# Altium schematic sheet 14-GPIO.SchDoc
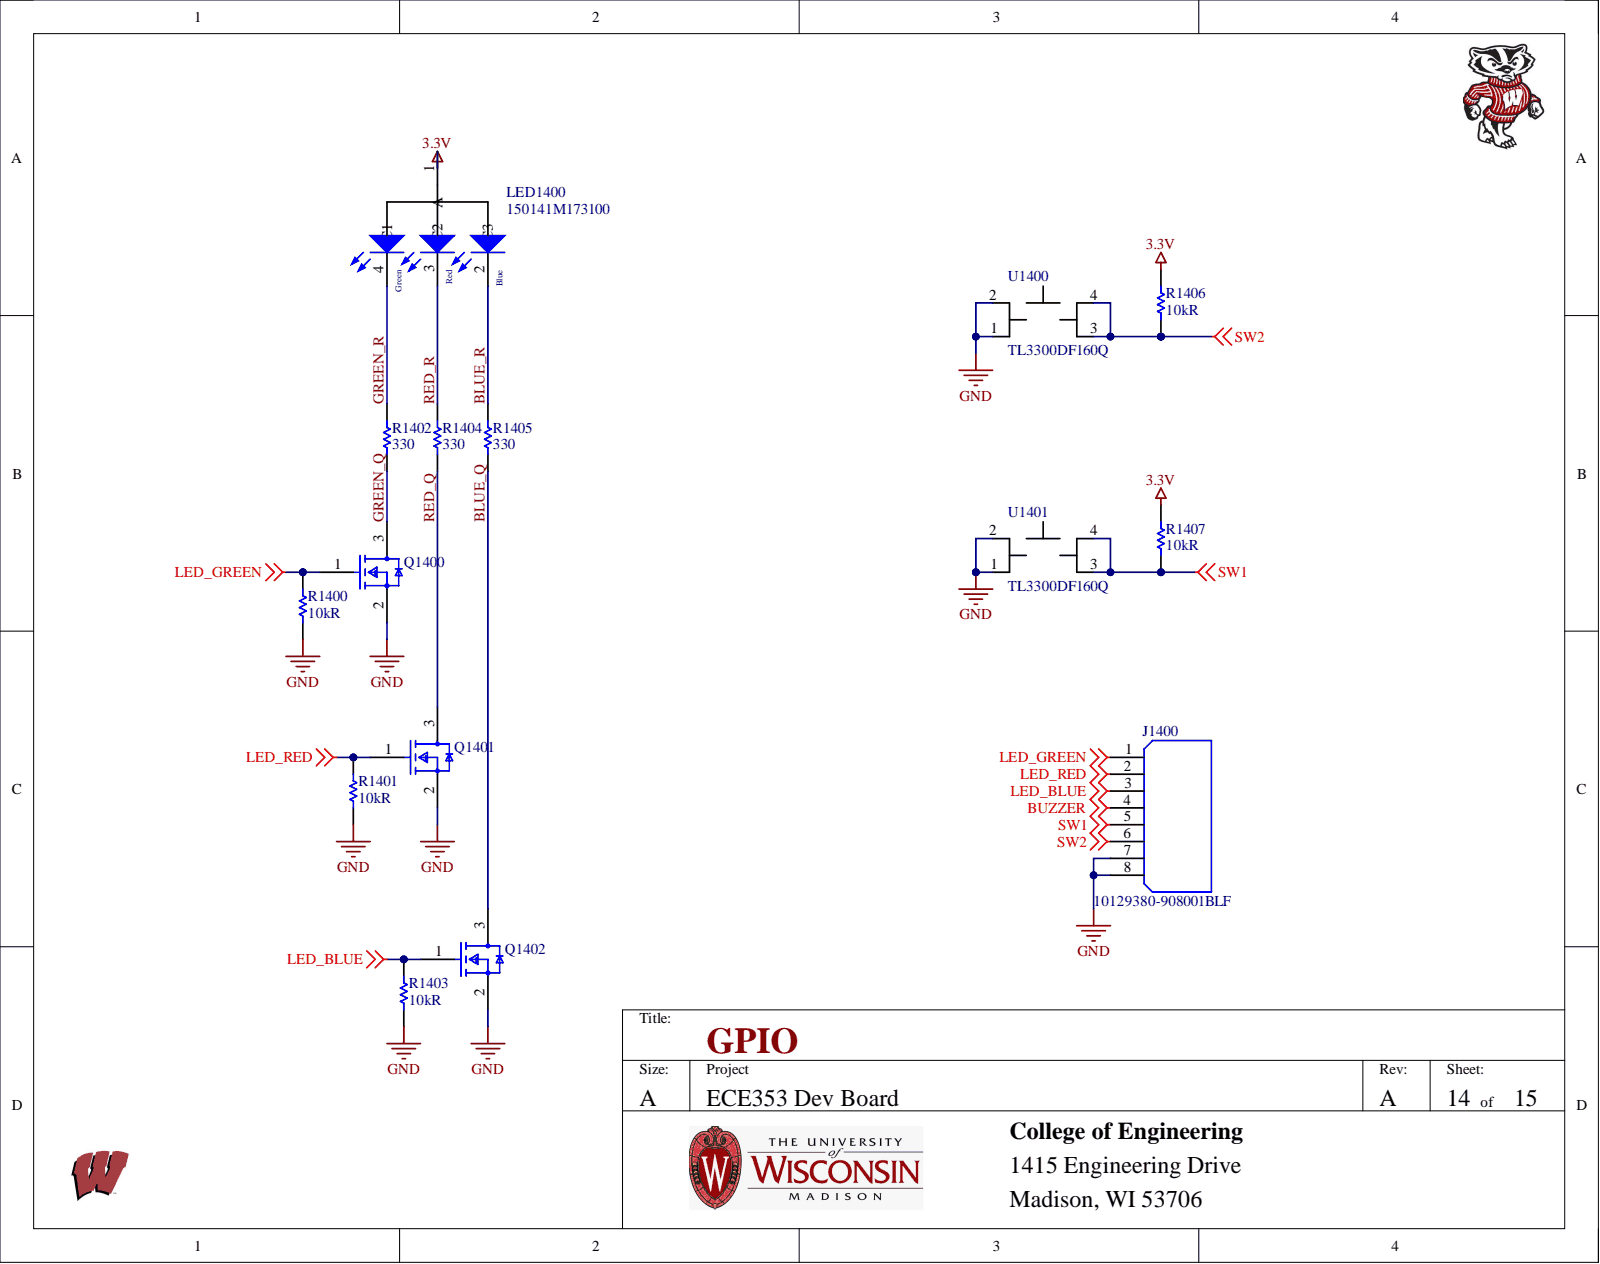
[diagram p0: 14-GPIO.SchDoc]

## Parts
J1400  10129380-908001BLF  [AMPHENOL_10129380-908001BLF]
LED1400  150141M173100  [3528_A]
Q1400  2N7002-7-F  [FP-SOT23-MFG]  (value not drawn)
Q1401  2N7002-7-F  [FP-SOT23-MFG]  (value not drawn)
Q1402  2N7002-7-F  [FP-SOT23-MFG]  (value not drawn)
R1400  Resistance: 10kR  [RESC2013X60X35NL10T20]  (hidden comment: RC0805FR-0710KL)
R1401  Resistance: 10kR  [RESC2013X60X35NL10T20]  (hidden comment: RC0805FR-0710KL)
R1402  RC0805FR-07330RL  [RESC2013X60X35LL10T20]  (value not drawn)
R1403  Resistance: 10kR  [RESC2013X60X35NL10T20]  (hidden comment: RC0805FR-0710KL)
R1404  RC0805FR-07330RL  [RESC2013X60X35LL10T20]  (value not drawn)
R1405  RC0805FR-07330RL  [RESC2013X60X35LL10T20]  (value not drawn)
R1406  Resistance: 10kR  [RESC2013X60X35NL10T20]  (hidden comment: RC0805FR-0710KL)
R1407  Resistance: 10kR  [RESC2013X60X35NL10T20]  (hidden comment: RC0805FR-0710KL)
U1400  TL3300DF160Q  [TL3300DF160Q]
U1401  TL3300DF160Q  [TL3300DF160Q]

## Nets
3.3V: LED1400.1(A) R1406.1 R1407.1
BUZZER: J1400.4
GND: J1400.7 J1400.8 Q1400.2 Q1401.2 Q1402.2 R1400.2 R1401.2 R1403.2 U1400.1 U1400.2 U1401.1 U1401.2
LED_BLUE: J1400.3 Q1402.1 R1403.1
LED_GREEN: J1400.1 Q1400.1 R1400.1
LED_RED: J1400.2 Q1401.1 R1401.1
SW1: J1400.5 R1407.2 U1401.3 U1401.4
SW2: J1400.6 R1406.2 U1400.3 U1400.4
BLUE_Q: Q1402.3 R1405.1
BLUE_R: LED1400.2(C3) R1405.2
GREEN_Q: Q1400.3 R1402.1
GREEN_R: LED1400.4(C1) R1402.2
RED_Q: Q1401.3 R1404.1
RED_R: LED1400.3(C2) R1404.2

## Library data of the parts
J1400 = 10129380-908001BLF: Conn Unshrouded Header HDR 8 POS 2.54mm Solder ST SMD Poly Bag; Manufacturer 1: ICC; MF: Amphenol ICC (FCI); Availability: In Stock; Check_prices: https://www.snapeda.com/parts/10129380-908001BLF/Amphenol+FCI/view-part/?ref=eda; Component Kind: Standard; Library Name: ece353-2022.SchLib; Manufacturer Part Number 1: 10129380-908001BLF; MP: 10129380-908001BLF; Package: None; Price: None; Purchase-URL: https://pricing.snapeda.com/search/part/10129380-908001BLF/?ref=eda; RoHS: No; SnapEDA_Link: https://www.snapeda.com/parts/10129380-908001BLF/Amphenol+FCI/view-part/?ref=snap; Supplier 1: Digi-Key; Supplier Part Number 1: 10129380-908001BLF-ND
LED1400 = CMP-1431-00001-1: SMD Full-Color Top LED, WL-SFTW, Red & Green & Blue; Manufacturer: Wuerth Elektronik; Manufacturer 1: Wurth Electronics; Dominant Wavelength: 470nm; Forward Current: 30mA; Forward Voltage: 3.2V; Lens Color: Colorless; Lens Size: 2.4mm; Lens Style: Clear,Round,Flat; Lens Transparency: Clear; Luminous Intensity: 230mcd; Test Current: 20mA; Viewing Angle: 120°; Case Code (Imperial): 1411; Case Code (Metric): 3528; Case/Package: 3528; Category: LEDs; Color: Red & Green & Blue; Component Kind: Standard; ComponentLink1Description: Manufacturer URL; ComponentLink1URL: http://www.we-online.com; ComponentLink2Description: Datasheet; ComponentLink2URL: http://katalog.we-online.com/led/datasheet/150141M173100.pdf; ComponentLink3Description: https://datasheet.ciiva.com/29562/150141m173100-29562961.pdf?src-supplier=Digi-Key; ComponentLink3URL: https://datasheet.ciiva.com/29562/150141m173100-29562961.pdf?src-supplier=Digi-Key; ComponentLink4Description: https://datasheet.ciiva.com/29278/759471-da-01-en-smd-topled-rgb-150141m173100-29278963.pdf?src-supplier=Conrad; ComponentLink4URL: https://datasheet.ciiva.com/29278/759471-da-01-en-smd-topled-rgb-150141m173100-29278963.pdf?src-supplier=Conrad; ComponentLink5Description: https://datasheet.ciiva.com/22653/1911491-22653029.pdf?src-supplier=Element14; ComponentLink5URL: https://datasheet.ciiva.com/22653/1911491-22653029.pdf?src-supplier=Element14; Height: 2.1mm; Length: 3.5mm; Library Name: Altium Content Vault; Manufacturer Part Number 1: 150141M173100; Max Operating Temperature: 85°C; Min Operating Temperature: -40°C; Mount: Surface Mount; Number of Pins: 4; Package Quantity: 1; PackageReference: 3528_A; Packaging: Cut Tape; PartNumber: 150141M173100; Pricing 1: 1=0.50000, 50=0.48900, 100=0.42600, 500=0.35500 (USD); RoHS: Yes; RoHS Compliant: Yes; Series: WL-SFTW; Stock 1: 13853; Supplier 1: Digi-Key; Supplier Part Number 1: 732-4998-1-ND; Wavelength: 470nm; Width: 2.8mm
Q1400-Q1402 = CMP-2000-04929-2: MOSFET N-CH 60V 115MA SOT23-3; Manufacturer 1: Diodes; Drain to Source Breakdown Voltage: 70V; Drain to Source Resistance: 7.5R; Element Configuration: Single; Fall Time: 5.6ns; Gate to Source Voltage (Vgs): 20V; Input Capacitance: 50pF; Max Power Dissipation: 300mW; Nominal Vgs: 2.5V; Number of Channels: 1; Polarity: N-CHANNEL; Power Dissipation: 540mW; Rise Time: 3ns; Turn-Off Delay Time: 11ns; Turn-On Delay Time: 7ns; Case/Package: SOT-23; Category: MOSFETs; Component Kind: Standard; ComponentLink1Description: https://datasheet.ciiva.com/15219/getdatasheetpartid-110059-15219667.pdf?src-supplier=Verical; ComponentLink1URL: https://datasheet.ciiva.com/15219/getdatasheetpartid-110059-15219667.pdf?src-supplier=Verical; ComponentLink2Description: https://datasheet.ciiva.com/3304/ds11303-3304385.pdf?src-supplier=Avnet; ComponentLink2URL: https://datasheet.ciiva.com/3304/ds11303-3304385.pdf?src-supplier=Avnet; ComponentLink3Description: https://datasheet.ciiva.com/1188/ds11303-1188363.pdf?src-supplier=Element14; ComponentLink3URL: https://datasheet.ciiva.com/1188/ds11303-1188363.pdf?src-supplier=Element14; ComponentLink4Description: https://datasheet.ciiva.com/22712/1915452-22712375.pdf?src-supplier=Element14; ComponentLink4URL: https://datasheet.ciiva.com/22712/1915452-22712375.pdf?src-supplier=Element14; ComponentLink5Description: https://datasheet.ciiva.com/49020/70437206-49020102.pdf?src-supplier=Allied+Electronics; ComponentLink5URL: https://datasheet.ciiva.com/49020/70437206-49020102.pdf?src-supplier=Allied+Electronics; Contact Plating: Tin; Continuous Drain Current (ID): 115mA; Current Rating: 115mA; Drain to Source Voltage (Vdss): 60V; Drain-source On Resistance-Max: 7.5R; Dual Supply Voltage: 60V; Height: 1mm; Lead Free: Lead Free; Length: 2.9mm; Library Name: Altium Content Vault; Manufacturer Part Number 1: 2N7002-7-F; Max Operating Temperature: 150°C; Min Operating Temperature: -55°C; Mount: Surface Mount; Number of Elements: 1; Number of Pins: 3; On-State Resistance: 7.5R; Package Quantity: 3000; Packaging: Tape and Reel; Pricing 1: 1=0.20000, 10=0.18600, 100=0.10140, 500=0.06236, 1000=0.04252 (USD); Radiation Hardening: No; Rds On Max: 7.5R; REACH SVHC: No SVHC; Reach SVHC Compliant: Yes; RoHS: Yes; RoHS Compliant: Yes; Series: -; Stock 1: 1441532; Supplier 1: Digi-Key; Supplier Part Number 1: 2N7002-FDICT-ND; Termination: SMD/SMT; Threshold Voltage: 2.5V; Voltage: 60V; Voltage Rating (DC): 60V; Weight: 0.000282oz; Width: 1.3mm
R1400 R1401 R1403 R1406 R1407 = CMP-1659-00013-4: Chip Resistor, 10 KOhm, +/- 1%, 0.125 W, -55 to 155 degC, 0805 (2012 Metric), RoHS, Tape and Reel; Manufacturer: Yageo; Max Power Dissipation: 125mW; Temperature Coefficient: 100ppm/°C; Voltage Rating: 150 V; Case Code (Imperial): 0805; Case Code (Metric): 2012; Case/Package: 0805; Category: Chip SMD Resistors; Component Kind: Standard; ComponentLink1Description: Manufacturer URL; ComponentLink1URL: http://www.yageo.com/; ComponentLink2Description: Datasheet; ComponentLink2URL: http://www.yageo.com/documents/recent/PYu-RC_Group_51_RoHS_L_04.pdf; Construction: Rectangular; DatasheetVersion: Rev. 4, 02/2016; Depth: 1.25mm; Features: Moisture Resistant; Height: 0.5 mm; Lead Free: Lead Free; Length: 2 mm; Library Name: Altium Content Vault; Manufacturer 1: Yageo; Manufacturer Part Number 1: RC0805FR-0710KL; Max Operating Temperature: 155 degC; Military Standard: Not; Min Operating Temperature: -55 degC; Mount: Surface Mount; Mounting Technology: Surface Mount; Number of Terminations: 2; Package Quantity: 5000; PackageDescription: 2-Pin Surface Mounted Device, Body 2 x 1.25 mm; PackageReference: 0805; Packaging: Tape and Reel; Pins: 2; Power Rating: 125mW; Pricing 1: 1=0.10000, 10=0.03700, 100=0.01520, 1000=0.00682, 2500=0.00592 (USD); REACH SVHC: No SVHC; Resistance Tolerance: 1%; Resistor Type: Thick Film; RoHS: Yes; RoHS Compliant: Yes; RoHSCompliant: true; Series: RC; Size Code: 0805; Stock 1: 12202331; Supplier 1: Digi-Key; Supplier Part Number 1: 311-10.0KCRCT-ND; Technology: THICK FILM; Termination: SMD/SMT; Tolerance: 1%; Width: 0.049inch
R1402 R1404 R1405 = CMP-2000-06960-1: Chip Resistor, 330 Ohm, +/- 1%, 125 mW, -55 to 155 degC, 0805 (2012 Metric), RoHS, Tape and Reel; Manufacturer: Yageo; Max Power Dissipation: 125mW; Power Rating: 125mW(1/8W); Resistance: 330; Temperature Coefficient: 100PPM/C; Voltage Rating: 150V; Case Code (Imperial): 0805; Case Code (Metric): 2012; Case Code - in: 0805; Case Code - mm: 2012; Case/Package: 0805; Category: Chip SMD Resistors; Component Kind: Standard; ComponentLink1Description: https://datasheet.ciiva.com/2457/pyu-r-int-thick-7-2457015.pdf?src-supplier=Digi-Key; ComponentLink1URL: https://datasheet.ciiva.com/2457/pyu-r-int-thick-7-2457015.pdf?src-supplier=Digi-Key; ComponentLink2Description: https://datasheet.ciiva.com/2457/pyu-r-int-thick-7-2457016.pdf?src-supplier=Digi-Key; ComponentLink2URL: https://datasheet.ciiva.com/2457/pyu-r-int-thick-7-2457016.pdf?src-supplier=Digi-Key; ComponentLink3Description: https://datasheet.ciiva.com/2457/pyu-r-int-thick-7-2457014.pdf?src-supplier=Digi-Key; ComponentLink3URL: https://datasheet.ciiva.com/2457/pyu-r-int-thick-7-2457014.pdf?src-supplier=Digi-Key; ComponentLink4Description: https://datasheet.ciiva.com/12667/rc0805-12667925.pdf?src-supplier=Mouser; ComponentLink4URL: https://datasheet.ciiva.com/12667/rc0805-12667925.pdf?src-supplier=Mouser; ComponentLink5Description: https://datasheet.ciiva.com/7941/444164-7941768.pdf?src-supplier=Element14; ComponentLink5URL: https://datasheet.ciiva.com/7941/444164-7941768.pdf?src-supplier=Element14; ComponentLink6Description: https://datasheet.ciiva.com/7941/444164-7941769.pdf?src-supplier=Element14; ComponentLink6URL: https://datasheet.ciiva.com/7941/444164-7941769.pdf?src-supplier=Element14; ComponentLink7Description: https://datasheet.ciiva.com/16740/rc0805-16740975.pdf?src-supplier=Future+Electronics; ComponentLink7URL: https://datasheet.ciiva.com/16740/rc0805-16740975.pdf?src-supplier=Future+Electronics; Construction: Rectangular; Datasheet URL: http://www.yageo.com/documents/recent/PYu-RC_Group_51_RoHS_L_7.pdf; Datasheet Version: Rev. 7, 05/2017; Depth: 1.25mm; Features: Moisture Resistant; Height: 0.024inch; Lead Free: Lead Free; Length: 0.079inch; Library Name: Altium Content Vault; Manufacturer 1: Yageo; Manufacturer Part Number 1: RC0805FR-07330RL; Manufacturer URL: http://www.yageo.com/; Max Operating Temperature: 155°C; Military Standard: Not; Min Operating Temperature: -55°C; Mounting Technology: SurfaceMount; Number of Terminations: 2; Operating Temperature Range: -55Cto+155C; Package Description: 2-Pin Surface Mounted Device, Body 2 x 1.25 mm; Package Quantity: 5000; Package Reference: 0805; Packaging: Reel; Pricing 1: 1=0.10000, 10=0.03700, 100=0.01510, 1000=0.00680, 2500=0.00590 (USD); Product: ThickFilmResistorsGeneralPurpose; Resistance Tolerance: 1%; Resistor Type: Thick Film; RoHS: Yes; RoHS Compliant: Yes; Series: RC; Size Code: 0805; Stock 1: 1297787; Supplier 1: Digi-Key; Supplier Part Number 1: 311-330CRCT-ND; Termination: SMD/SMT; Termination Style: SMD/SMT; Tolerance: 1%; Width: 0.049inch
U1400 U1401 = TL3300DF160Q: Switch Tactile OFF (ON) SPST Round Button Gull Wing 0.05A 12VDC 1.57N SMD; Manufacturer: E-Switch; Actuator Height off PCB, from Pins: 4.30mm; Actuator Orientation: Top Actuated; Actuator Type: Standard; Circuit: SPST-NO; Contact Rating @ Voltage: 0.05A @ 12VDC; Current Rating: 50mA; Illumination: Non-Illuminated; Mechanical Life: 200,000 Cycles; Operating Force: 160gf; Outline: 12.00mm x 12.00mm; Switch Function: Off-Mom; Switch Travel: 0.35mm; Voltage Rating (DC): 12V; Actuator Material: -; Case/Package: SMD/SMT; Category: Switches; Component Kind: Standard; ComponentLink1Description: https://datasheet.ciiva.com/2900/n301101-2900856.pdf?src-supplier=Digi-Key; ComponentLink1URL: https://datasheet.ciiva.com/2900/n301101-2900856.pdf?src-supplier=Digi-Key; ComponentLink2Description: https://datasheet.ciiva.com/2900/n301101-2900858.pdf?src-supplier=Digi-Key; ComponentLink2URL: https://datasheet.ciiva.com/2900/n301101-2900858.pdf?src-supplier=Digi-Key; Contact Plating: Silver; Features: -; Illumination Type: Non-Illuminated; Illumination Type, Color: -; Illumination Voltage (Nominal): -; Ingress Protection: -; Library Name: ece353-2016.SchLib; Manufacturer 1: E-Switch; Manufacturer Part Number: TL3300DF160Q; Manufacturer Part Number 1: TL3300DF160Q; Max Operating Temperature: 70°C; Min Operating Temperature: -25°C; Mount: Surface Mount; Mounting Type: Surface Mount; Operating Temperature: -25°C ~ 70°C; Package Quantity: 1; Packaging: Cut Tape (CT); Pricing 1: 1=0.60000, 10=0.57800, 25=0.55680, 100=0.48180, 250=0.43896 (USD); RoHS: RoHS Compliant; RoHS Compliant: Yes; Series: TL3300; Stock 1: 57403; Supplier: Digi-Key; Supplier 1: Digi-Key; Supplier Part Number: EG4906CT-ND; Supplier Part Number 1: EG4906CT-ND; Termination: Gull Wing; Termination Style: Gull Wing; Throw Configuration: SPST
